# Altium schematic sheet Memory.SchDoc
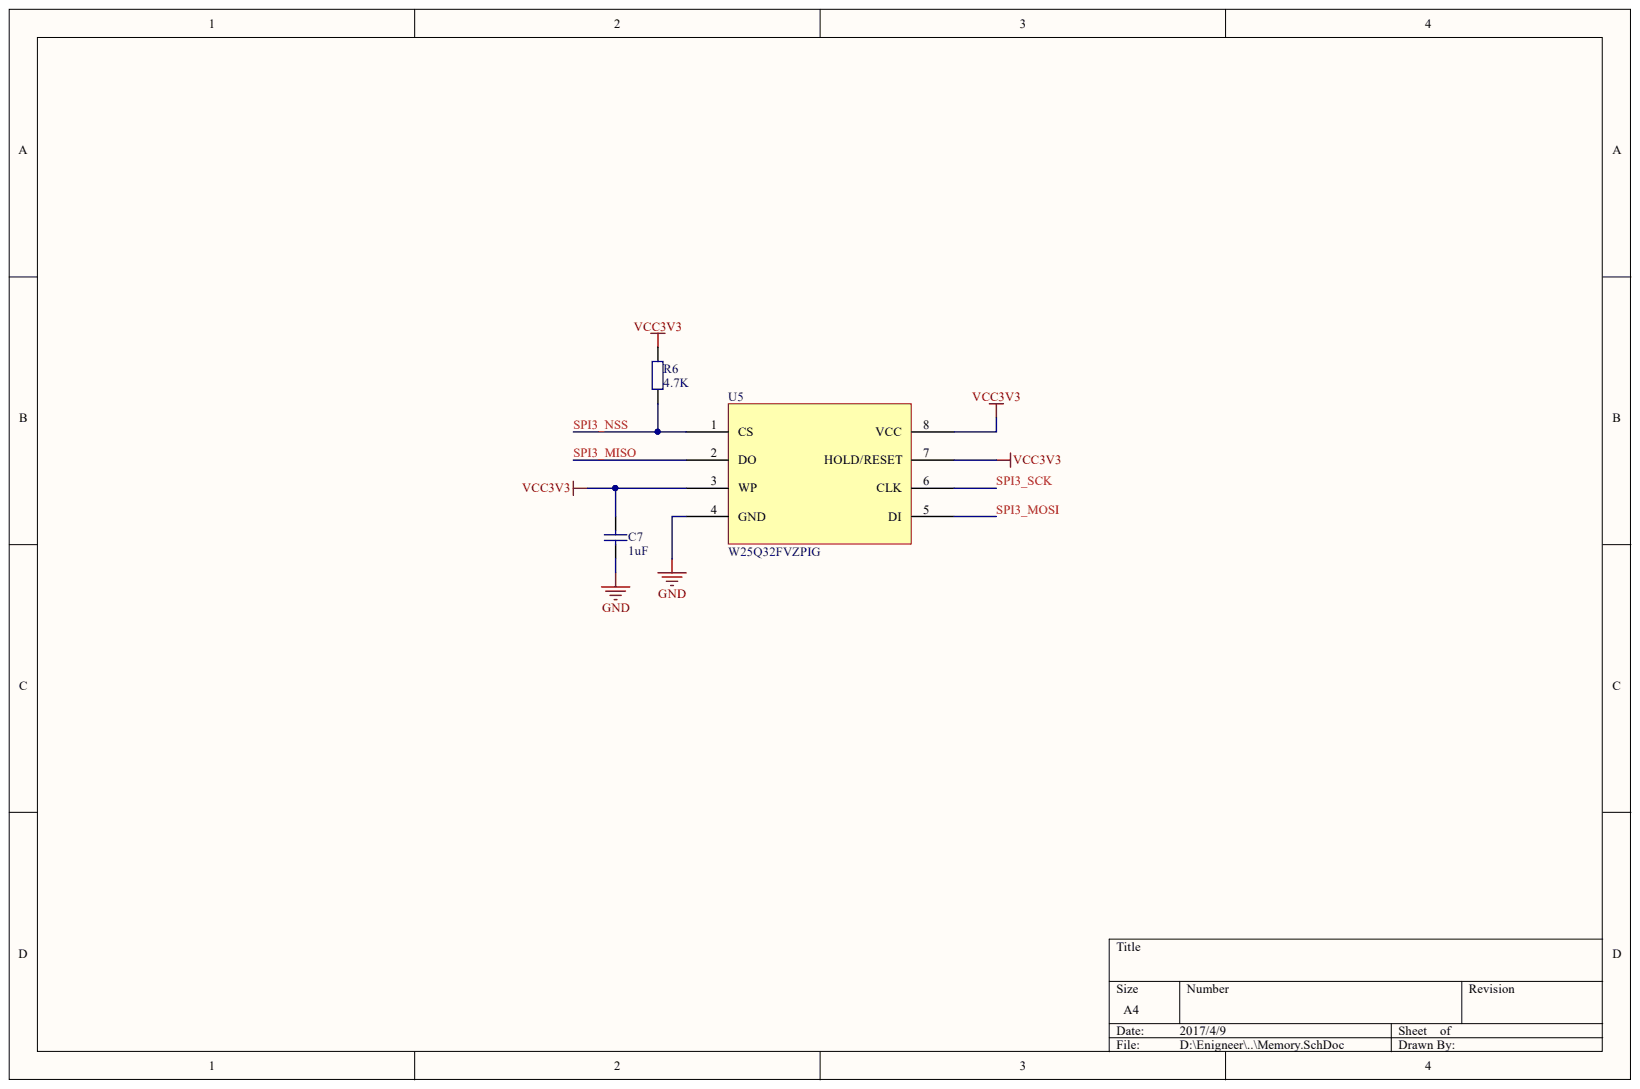
[diagram p0: Memory.SchDoc]

## Parts
C7  value 1uF  [0603C]  (hidden comment: Cap)
R6  value 4.7K  [0603R]  (hidden comment: Res2)
U5  W25Q32FVZPIG  [W25QFVZPIG-SON]

## Nets
GND: C7.1 U5.4(GND)
VCC3V3: C7.2 R6.1 U5.3(WP) U5.7(HOLD/RESET) U5.8(VCC)
SPI3_MISO: U5.2(DO)
SPI3_MOSI: U5.5(DI)
SPI3_NSS: R6.2 U5.1(CS)
SPI3_SCK: U5.6(CLK)

## Library data of the parts
C7 = Cap: Capacitor; Component Kind: Standard; LatestRevisionDate: 17-Jul-2002; LatestRevisionNote: Re-released for DXP Platform.; Library Name: Miscellaneous Devices.IntLib; PackageDescription: Radial Cap, Thru-Hole; 2 Leads; 0.3 in Pin Spacing; PackageReference: RAD-0.3; Published: 8-Jun-2000; Signal Integrity: Cap; Simulation: CAP; Publisher: Altium Limited
R6 = Res2: Resistor; Component Kind: Standard; LatestRevisionDate: 17-Jul-2002; LatestRevisionNote: Re-released for DXP Platform.; Library Name: Miscellaneous Devices.IntLib; PackageDescription: Axial Device, Thru-Hole; 2 Leads; 0.4 in Pin Spacing; PackageReference: AXIAL-0.4; Published: 8-Jun-2000; Signal Integrity: Res; Simulation: RESISTOR; Publisher: Altium Limited
U5 = W25Q32FV: Component Kind: Standard; Library Name: pxLib.SchLib
